# Altium schematic sheet Power Board-LM5050.SchDoc
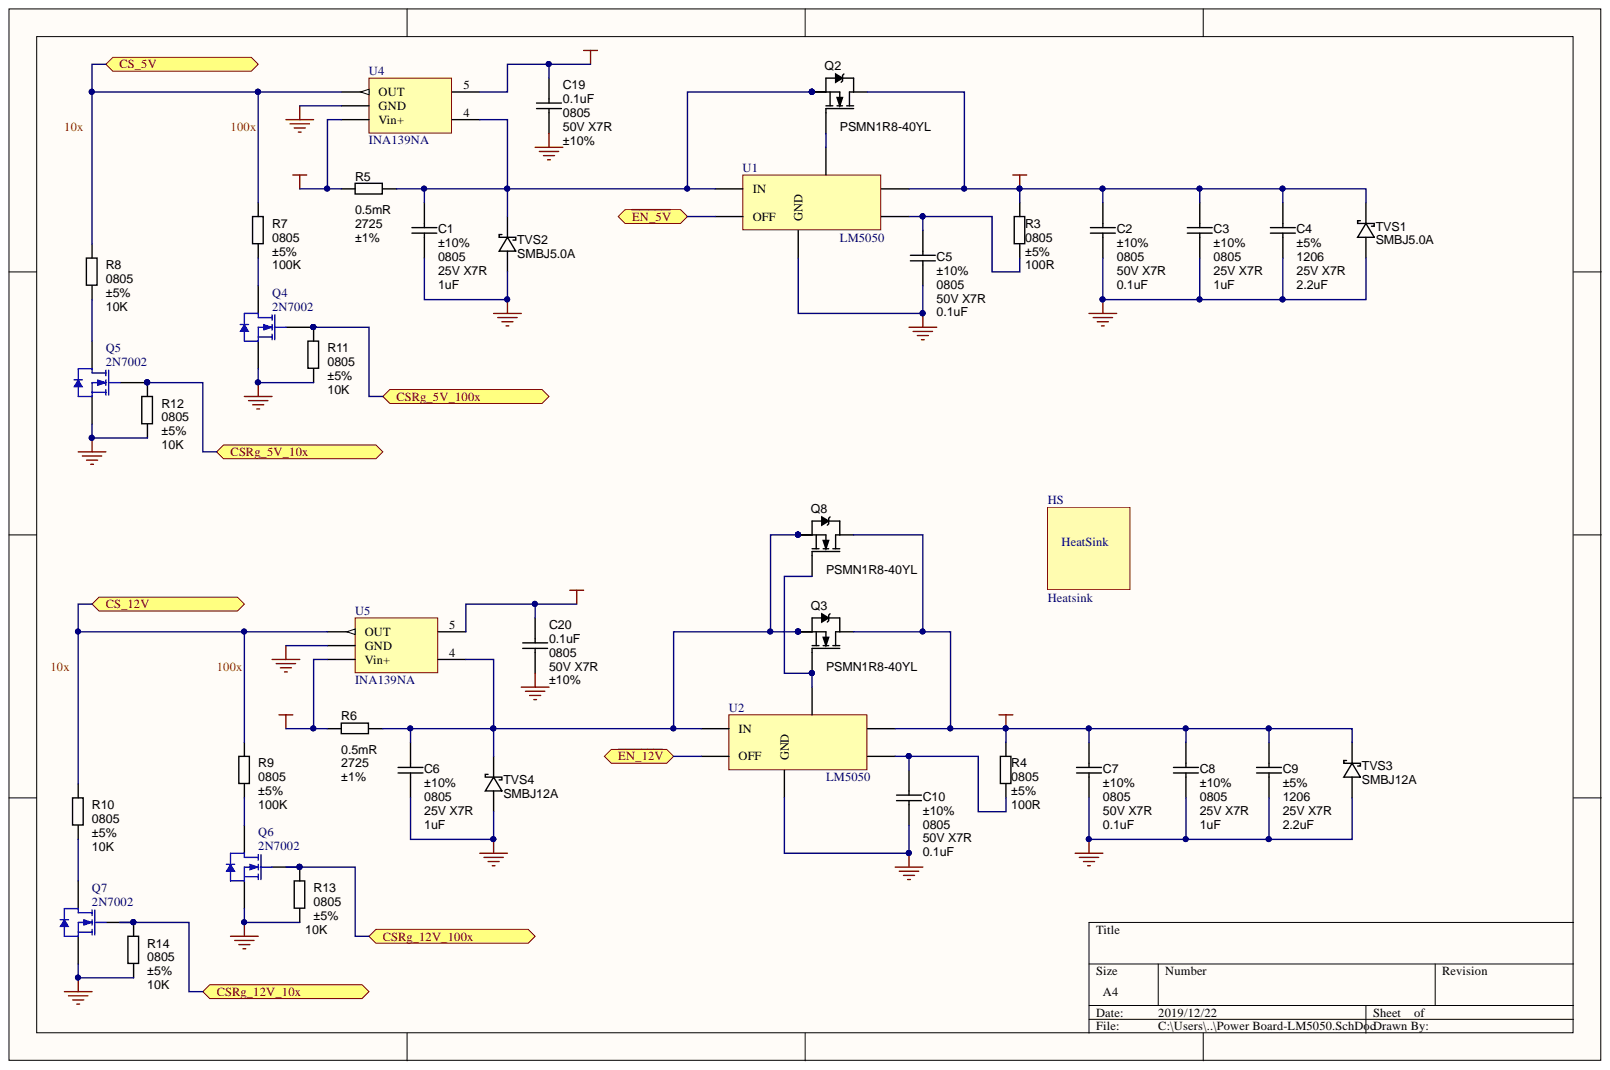
[diagram p0: Power Board-LM5050.SchDoc]

## Parts
C1  value 1uF  Package / Case: 0805  Tolerance: ±10%  [C0805-EIA-LevelB]  (hidden comment: approved sub OK)
C2  value 0.1uF  Package / Case: 0805  Tolerance: ±10%  [C0805-EIA-LevelB]  (hidden comment: approved sub OK)
C3  value 1uF  Package / Case: 0805  Ratings: 25V X7R  Tolerance: ±10%  [C0805-EIA-LevelB]  (hidden comment: approved sub OK)
C4  value 2.2uF  Package / Case: 1206  Ratings: 25V X7R  Tolerance: ±5%  [C1206-EIA-LevelB]  (hidden comment: approved sub OK)
C5  value 0.1uF  Package / Case: 0805  Tolerance: ±10%  [C0805-EIA-LevelB]  (hidden comment: approved sub OK)
C6  value 1uF  Package / Case: 0805  Tolerance: ±10%  [C0805-EIA-LevelB]  (hidden comment: approved sub OK)
C7  value 0.1uF  Package / Case: 0805  Tolerance: ±10%  [C0805-EIA-LevelB]  (hidden comment: approved sub OK)
C8  value 1uF  Package / Case: 0805  Ratings: 25V X7R  Tolerance: ±10%  [C0805-EIA-LevelB]  (hidden comment: approved sub OK)
C9  value 2.2uF  Package / Case: 1206  Ratings: 25V X7R  Tolerance: ±5%  [C1206-EIA-LevelB]  (hidden comment: approved sub OK)
C10  value 0.1uF  Package / Case: 0805  Tolerance: ±10%  [C0805-EIA-LevelB]  (hidden comment: approved sub OK)
C19  value 0.1uF  Package / Case: 0805  Tolerance: ±10%  [C0805-EIA-LevelB]  (hidden comment: approved sub OK)
C20  value 0.1uF  Package / Case: 0805  Tolerance: ±10%  [C0805-EIA-LevelB]  (hidden comment: approved sub OK)
HS  Heatsink  [Heatsink03]
Q2  PSMN1R8-40YL  [SOT669]
Q3  PSMN1R8-40YL  [SOT669]
Q4  2N7002  [SOT23]
Q5  2N7002  [SOT23]
Q6  2N7002  [SOT23]
Q7  2N7002  [SOT23]
Q8  PSMN1R8-40YL  [SOT669]
R3  value 100R  Package / Case: 0805  Tolerance: ±5%  [RCS0805-e3]  (hidden comment: Thick Film)
R4  value 100R  Package / Case: 0805  Tolerance: ±5%  [RCS0805-e3]  (hidden comment: Thick Film)
R5  value 0.5mR  Package / Case: 2725  [RESC6865X12N-R2725]  (hidden comment: RES_0.5mR_2725_1%)
R6  value 0.5mR  Package / Case: 2725  [RESC6865X12N-R2725]  (hidden comment: RES_0.5mR_2725_1%)
R7  value 100K  Package / Case: 0805  Tolerance: ±5%  [RCS0805-e3]  (hidden comment: RES_100K_0805_5%)
R8  value 10K  Package / Case: 0805  Tolerance: ±5%  [RCS0805-e3]  (hidden comment: Thick Film)
R9  value 100K  Package / Case: 0805  Tolerance: ±5%  [RCS0805-e3]  (hidden comment: RES_100K_0805_5%)
R10  value 10K  Package / Case: 0805  Tolerance: ±5%  [RCS0805-e3]  (hidden comment: Thick Film)
R11  value 10K  Package / Case: 0805  Tolerance: ±5%  [RCS0805-e3]  (hidden comment: Thick Film)
R12  value 10K  Package / Case: 0805  Tolerance: ±5%  [RCS0805-e3]  (hidden comment: Thick Film)
R13  value 10K  Package / Case: 0805  Tolerance: ±5%  [RCS0805-e3]  (hidden comment: Thick Film)
R14  value 10K  Package / Case: 0805  Tolerance: ±5%  [RCS0805-e3]  (hidden comment: Thick Film)
TVS1  SMBJ5.0A  [DO-214AA]
TVS2  SMBJ5.0A  [DO-214AA]
TVS3  SMBJ12A  [DO-214AA]
TVS4  SMBJ12A  [DO-214AA]
U1  LM5050  [SOT95P280X110-6N]
U2  LM5050  [SOT95P280X110-6N]
U4  INA139NA  [SOT95P280X110-5N]
U5  INA139NA  [SOT95P280X110-5N]

## Ports
CS_5V, CS_12V, CSRg_5V_10x, CSRg_5V_100x, CSRg_12V_10x, CSRg_12V_100x, ~{EN_5V}, ~{EN_12V}

## Nets
5VBUS: C2.1 C3.1 C4.1 Q2.mb R3.1 TVS1.K U1.6
5Vin: R5.1 U4.3(Vin+)
12VBUS: C7.1 C8.1 C9.1 Q3.mb Q8.mb R4.1 TVS3.K U2.6
12Vin: R6.1 U5.3(Vin+)
GND: C1.2 C2.2 C3.2 C4.2 C5.2 C6.2 C7.2 C8.2 C9.2 C10.2 C19.2 C20.2 Q4.2 Q5.2 Q6.2 Q7.2 R11.2 R12.2 R13.2 R14.2 TVS1.A TVS2.A TVS3.A TVS4.A U1.2(GND) U2.2(GND) U4.2(GND) U5.2(GND)
P3V3: C19.1 C20.1 U4.5 U5.5
~{EN_5V}: U1.3(OFF) ; port ~{EN_5V}
CS_5V: R7.1 R8.1 U4.1(OUT) ; port CS_5V
CS_12V: R9.1 R10.1 U5.1(OUT) ; port CS_12V
CSRg_5V_10x: Q5.1 R12.1 ; port CSRg_5V_10x
CSRg_5V_100x: Q4.1 R11.1 ; port CSRg_5V_100x
CSRg_12V_10x: Q7.1 R14.1 ; port CSRg_12V_10x
CSRg_12V_100x: Q6.1 R13.1 ; port CSRg_12V_100x
~{EN_12V}: U2.3(OFF) ; port ~{EN_12V}
NetC1_1: C1.1 Q2.1 Q2.2 Q2.3 R5.2 TVS2.K U1.4(IN) U4.4
NetC5_1: C5.1 R3.2 U1.1
NetC6_1: C6.1 Q3.1 Q3.2 Q3.3 Q8.1 Q8.2 Q8.3 R6.2 TVS4.K U2.4(IN) U5.4
NetC10_1: C10.1 R4.2 U2.1
NetQ2_4: Q2.4 U1.5
NetQ3_4: Q3.4 Q8.4 U2.5
NetQ4_3: Q4.3 R7.2
NetQ5_3: Q5.3 R8.2
NetQ6_3: Q6.3 R9.2
NetQ7_3: Q7.3 R10.2

## Library data of the parts
C1 C6 = CAPC_1uF_25V_X7R_0805_10%: Capacitor SMD Cer 1uF 25V X7R 0805 10%; Manufacturer 1: Murata Electronics; Manufacturer Part Number 1: GCJ21BR71E105KA12L; Ratings: 25V X7R; SCDType: undef; Component Kind: Standard; Operating Temperature: -55°C ~ 125°C; Library Name: roger225_Altium_Library.IntLib
C2 C5 C7 C10 C19 C20 = CAPC_0.1uF_50V_X7R_0805_10%: Capacitor SMD Cer 0.1uF 50V X7R 0805 10% 100nF; Manufacturer 1: Murata Electronics; Manufacturer Part Number 1: GCD21BR71H104KA01L; Ratings: 50V X7R; SCDType: undef; Component Kind: Standard; Operating Temperature: -55°C ~ 125°C; Library Name: roger225_Altium_Library.IntLib
C3 C8 = CAPC_1uF_25V_X7R_0805_10%: Capacitor SMD Cer 1uF 25V X7R 0805 10%; Manufacturer 1: Murata Electronics; Manufacturer Part Number 1: GCJ21BR71E105KA12L; SCDType: undef; Component Kind: Standard; Operating Temperature: -55°C ~ 125°C; Library Name: roger225_Altium_Library.IntLib
C4 C9 = CAPC_2.2uF_25V_X7R_1206_5%: Capacitor SMD Cer 2.2uF 25V X7R 1206 5%; Manufacturer 1: Murata Electronics; Manufacturer Part Number 1: GCG31MR71E225JA12L; SCDType: undef; Component Kind: Standard; Operating Temperature: -55°C ~ 125°C; Library Name: roger225_Altium_Library.IntLib
Q2 Q3 Q8 = MOSFET_N-CH_PSMN1R8-40YL: MOSFET N-Channel Power Switching 40V 100A  Power-SO8-4 SMD; Manufacturer 1: Nexperia; Ratings: 40V; 100A; 272W; Rds On (Max) @ Id, Vgs: 1.5 mOhm @ 25A, 10V; Rds On (Max) @ Id, Vgs: 1.8 mOhm @ 25A, 4.5V; Vgs(th) (Max) @ Id: 1.95V @ 1mA; Component Kind: Standard; ComponentLink1URL: http://www.mouser.com/ds/2/302/PSMN1R8-40YLC-842682.pdf; Current - Continuous Drain (Id) @ 25° C: 100A; Drain to Source Voltage (Vdss): 40V; Manufacturer Part Number 1: PSMN1R8-40YL; Package / Case: SOT-669; Library Name: roger225_Altium_Library.IntLib
Q4-Q7 = 2N7002: N-channel Enhancement Mode Field-effect Transistor; Component Kind: Standard; ComponentLink1Description: Manufacturer Link; ComponentLink1URL: http://www.semiconductors.philips.com/; ComponentLink2Description: Datasheet; ComponentLink2URL: http://www.semiconductors.philips.com/acrobat/datasheets/2N7002_4.pdf; DatasheetVersion: 27-Jul-2000; PackageDescription: SOT-23; 3 Leads; Body 3.0 x 2.5 mm, inc. leads (LxW max); PackageReference: SOT23; PackageVersion: May-1999; Published: 17-Sep-2003; Simulation: 2N7002; Library Name: roger225_Altium_Library.IntLib; Publisher: Altium Limited
R3 R4 = RES_100R_0805_5%: Resistor SMD chip 100 Ohm 0.125W 5% 0805; Ratings: 1/8W; SCDType: spec; Component Kind: Standard; Supplier 1: Digi-Key; Supplier Part Number 1: 541-1.00KCCT-ND; Library Name: roger225_Altium_Library.IntLib
R5 R6 = RES_0.5mR_2725_1%: Resistor SMD chip 0.5 mOhm 4W 1% 2725; SCDType: spec; Component Kind: Standard; Manufacturer 1: -; Manufacturer Part Number: -; Ratings: 4W; Supplier Part Number 1: -; Tolerance: ±1%; Library Name: roger225_Altium_Library.IntLib
R7 R9 = RES_100K_0805_5%: Resistor SMD chip 100k Ohm 0.125W 5% 0805; Ratings: 1/8W; SCDType: spec; Component Kind: Standard; Library Name: roger225_Altium_Library.IntLib
R8 R10-R14 = RES_10K_0805_5%: Resistor SMD chip 10k Ohm 0.125W 5% 0805; Manufacturer 1: Vishay/Dale; Manufacturer Part Number 1: CRCW08051K00FKEA; Ratings: 1/8W; SCDType: spec; Component Kind: Standard; Supplier 1: Digi-Key; Supplier Part Number 1: 541-1.00KCCT-ND; Library Name: roger225_Altium_Library.IntLib
TVS1 TVS2 = TVS_SMBJ5.0A: Transient_Voltage_Suppressors 5V 600W DO-214AA; Component Kind: Standard; Package / Case: DO-214AA, SMB; Library Name: roger225_Altium_Library.IntLib
TVS3 TVS4 = TVS_SMBJ12A: Transient_Voltage_Suppressors 12V 600W DO-214AA; Component Kind: Standard; Package / Case: DO-214AA, SMB; Library Name: roger225_Altium_Library.IntLib
U1 U2 = LM5050 OR-ing FET Controller: Component Kind: Standard; Description: LM5050 OR-ing FET Controller; Library Name: LM5050 OR-ing FET Controller.IntLib
HS = Heatsink: Component Kind: Standard; Library Name: Heatsink.IntLib
U4 U5 = INA139NA: Component Kind: Standard; Description: INA139NA; Library Name: INA139NA.IntLib
